# Altium schematic sheet DRIVER_V1.0.SchDot
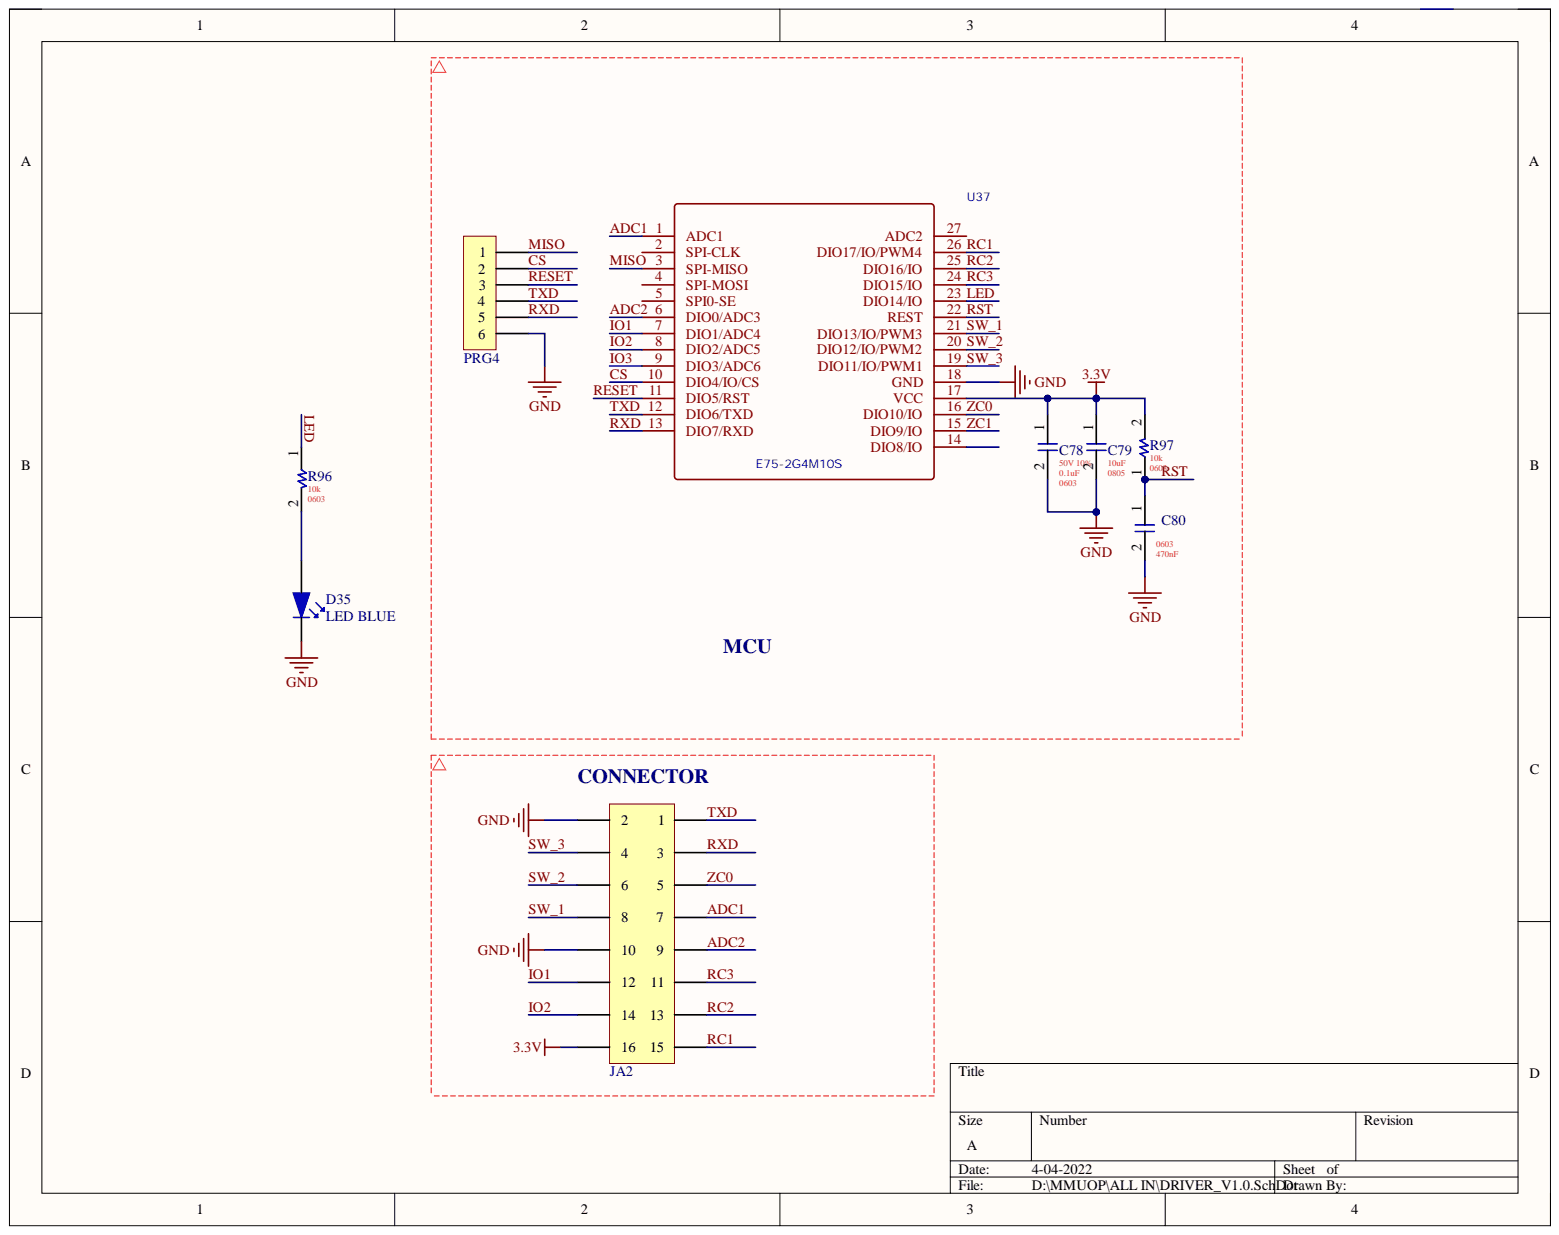
[diagram p0: DRIVER_V1.0.SchDot]

## Parts
C78  0.1uF  FP: 0603  VOLTAGE + TOLE: 50V 10%  [0603 C]
C79  10uF  FP: 0805  [0805 C]
C80  470nF  FP: 0603  [0603 C]
D35  LED BLUE  [LED0603_1608]
JA2  rao doi  [T]  (value not drawn)
PRG4  P6  [P6 - 1.27MM]  (value not drawn)
R96  10k  FP: 0603  [0603 R]
R97  10k  FP: 0603  [0603 R]
U37  E75-2G4M10S  [NXP-E75-10S]

## Nets
3.3V: C78.1 C79.1 JA2.16 R97.2 U37.17(VCC)
GND: C78.2 C79.2 C80.2 D35.2 JA2.2 JA2.10 PRG4.6 U37.18(GND)
ADC1: JA2.7 U37.1(ADC1)
ADC2: JA2.9 U37.6(DIO0/ADC3)
CS: PRG4.2 U37.10(DIO4/IO/CS)
IO1: JA2.12 U37.7(DIO1/ADC4)
IO2: JA2.14 U37.8(DIO2/ADC5)
IO3: U37.9(DIO3/ADC6)
LED: R96.1 U37.23(DIO14/IO)
MISO: PRG4.1 U37.3(SPI-MISO)
RC1: JA2.15 U37.26(DIO17/IO/PWM4)
RC2: JA2.13 U37.25(DIO16/IO)
RC3: JA2.11 U37.24(DIO15/IO)
RESET: PRG4.3 U37.11(DIO5/RST)
RST: C80.1 R97.1 U37.22(REST)
RXD: JA2.3 PRG4.5 U37.13(DIO7/RXD)
SW_1: JA2.8 U37.21(DIO13/IO/PWM3)
SW_2: JA2.6 U37.20(DIO12/IO/PWM2)
SW_3: JA2.4 U37.19(DIO11/IO/PWM1)
TXD: JA2.1 PRG4.4 U37.12(DIO6/TXD)
ZC0: JA2.5 U37.16(DIO10/IO)
ZC1: U37.15(DIO9/IO)
NetD35_1: D35.1 R96.2

Not connected: U37 2(SPI-CLK) 4(SPI-MOSI) 5(SPI0-SE) 14(DIO8/IO) 27(ADC2)

## Library data of the parts
C78 = 0603 0.1uF CER: CAP CER 0.1uF 50V 10% 0603 SMD; Component Kind: Standard; ComponentLink1Description: New link; DATE: 04-Mar-21; Library Name: Capacitor.SchLib; PACKAGE: SMD; VALUE: 0.1uF; CREATOR: MMUOP
C79 = 0805 10uF CER: CAP CER 10uF 50V 10% 0805 SMD; VOLTAGE + TOLE: 50V 10%; Component Kind: Standard; ComponentLink1Description: New link; DATE: 04-Mar-21; Library Name: Capacitor.SchLib; PACKAGE: SMD; VALUE: 10uF; CREATOR: MMUOP
C80 = 0603 470nF CER: CAP CER 470nF 50V 10% 0603 SMD; VOLTAGE + TOLE: 50V 10%; Component Kind: Standard; ComponentLink1Description: New link; DATE: 04-Mar-21; Library Name: Capacitor.SchLib; PACKAGE: SMD; VALUE: 470nF; CREATOR: MMUOP
D35 = LED smd: Component Kind: Standard; Library Name: Kienlib.SchLib
JA2 = P2x8: Component Kind: Standard; Library Name: Kienlib.SchLib
R96 R97 = 0603 10k 1%: 10k 1% 0603 SMD; PN: C98220; Component Kind: Standard; ComponentLink1Description: New link; DATE: 04-Mar-21; Library Name: Res.SchLib; PACKAGE: SMD; VALUE: 10k; VOLTAGE + TOLE: 1%; CREATOR: MMUOP
PRG4 = P6: Component Kind: Standard; Library Name: Kienlib.SchLib
U37 = E75-2G4M10S: Component Kind: Standard
